# Altium schematic sheet Power.SchDoc(Power)
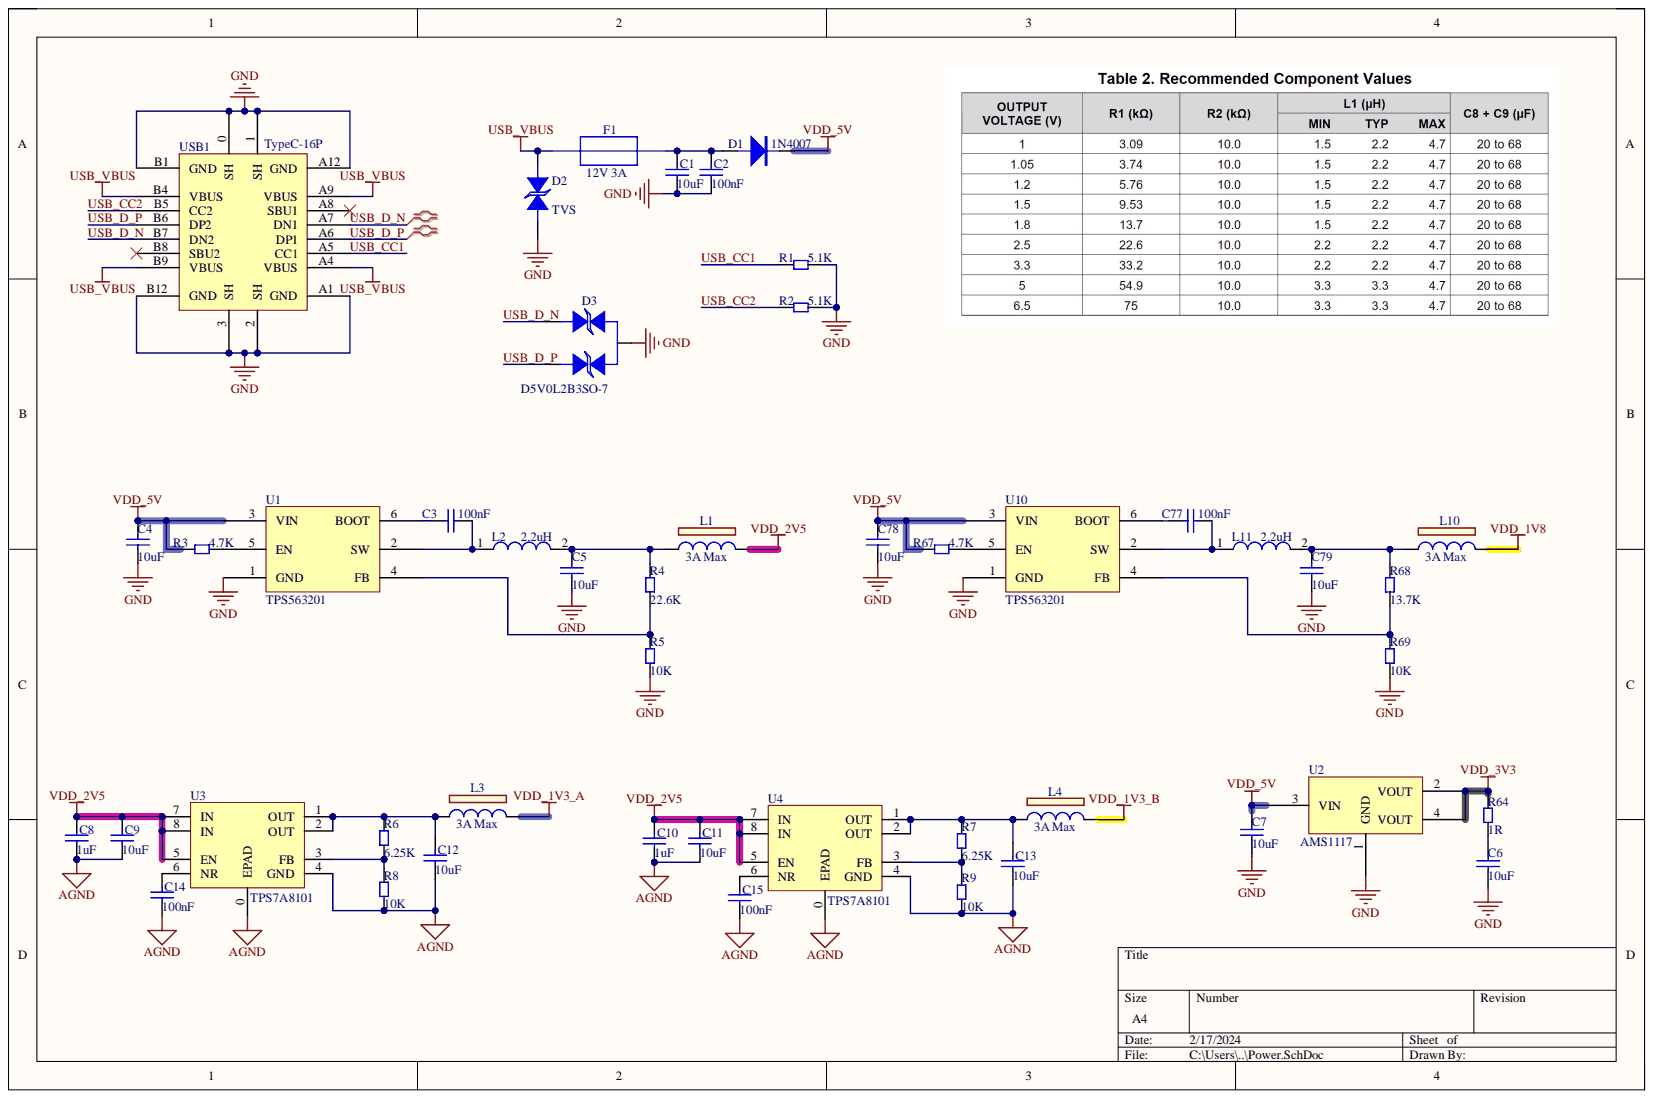
[diagram p0: Power.SchDoc]

## Parts
C1  10uF  [C0603]
C2  100nF  [C0603]
C3  100nF  [C0402]
C4  10uF  [C0603]
C5  10uF  [C0402]
C6  10uF  [C0603]
C7  10uF  [C0603]
C8  1uF  [C0402]
C9  10uF  [C0402]
C10  1uF  [C0402]
C11  10uF  [C0402]
C12  10uF  [C0402]
C13  10uF  [C0402]
C14  100nF  [C0402]
C15  100nF  [C0402]
C77  100nF  [C0402]
C78  10uF  [C0603]
C79  10uF  [C0402]
D1  1N4007  [SOD-123]
D2  TVS  [SOD-123]
D3  D5V0L2B3SO-7  [SOT23-3]
F1  12V 3A  [R0603]
L1  3A Max  [L0603]
L2  2.2uH  [SMD-2520]
L3  3A Max  [L0603]
L4  3A Max  [L0603]
L10  3A Max  [L0603]
L11  2.2uH  [SMD-2520]
R1  5.1K  [R0402]
R2  5.1K  [R0402]
R3  4.7K  [R0402]
R4  22.6K  [R0402]
R5  10K  [R0402]
R6  6.25K  [R0402]
R7  6.25K  [R0402]
R8  10K  [R0402]
R9  10K  [R0402]
R64  1R  [R0402]
R67  4.7K  [R0402]
R68  13.7K  [R0402]
R69  10K  [R0402]
U1  TPS563201  [SOT23-6]
U2  AMS1117  [SOT223-3]
U3  TPS7A8101  [VSON-8-EP]
U4  TPS7A8101  [VSON-8-EP]
U10  TPS563201  [SOT23-6]
USB1  TypeC-16P  [TypeC-16P]

## Nets
AGND: C8.1 C9.1 C10.1 C11.1 C12.1 C13.1 C14.1 C15.1 R8.1 R9.1 U3.0(EPAD) U3.4(GND) U4.0(EPAD) U4.4(GND)
GND: C1.1 C2.1 C4.1 C5.1 C6.1 C7.1 C78.1 C79.1 D2.2 D3.3 R1.1 R2.1 R5.1 R69.1 U1.1(GND) U2.1(GND) U10.1(GND) USB1.0(SH) USB1.1(SH) USB1.2(SH) USB1.3(SH) USB1.A1(GND) USB1.A12(GND) USB1.B1(GND) USB1.B12(GND)
USB_VBUS: D2.1 F1.1 USB1.A4(VBUS) USB1.A9(VBUS) USB1.B4(VBUS) USB1.B9(VBUS)
VDD_1V3_A: L3.2
VDD_1V3_B: L4.2
VDD_1V8: L10.2
VDD_2V5: C8.2 C9.2 C10.2 C11.2 L1.2 U3.5(EN) U3.7(IN) U3.8(IN) U4.5(EN) U4.7(IN) U4.8(IN)
VDD_3V3: R64.2 U2.2(VOUT) U2.4(VOUT)
VDD_5V: C4.2 C7.2 C78.2 D1.1 R3.2 R67.2 U1.3(VIN) U2.3(VIN) U10.3(VIN)
USB_CC1: R1.2 USB1.A5(CC1)
USB_CC2: R2.2 USB1.B5(CC2)
USB_D_N: D3.1 USB1.A7(DN1) USB1.B7(DN2)
USB_D_P: D3.2 USB1.A6(DP1) USB1.B6(DP2)
NetC1_2: C1.2 C2.2 D1.2 F1.2
NetC3_1: C3.1 L2.1 U1.2(SW)
NetC3_2: C3.2 U1.6(BOOT)
NetC5_2: C5.2 L1.1 L2.2 R4.2
NetC6_2: C6.2 R64.1
NetC12_2: C12.2 L3.1 R6.2 U3.1(OUT) U3.2(OUT)
NetC13_2: C13.2 L4.1 R7.2 U4.1(OUT) U4.2(OUT)
NetC14_2: C14.2 U3.6(NR)
NetC15_2: C15.2 U4.6(NR)
NetC77_1: C77.1 L11.1 U10.2(SW)
NetC77_2: C77.2 U10.6(BOOT)
NetC79_2: C79.2 L10.1 L11.2 R68.2
NetR3_1: R3.1 U1.5(EN)
NetR4_1: R4.1 R5.2 U1.4(FB)
NetR6_1: R6.1 R8.2 U3.3(FB)
NetR7_1: R7.1 R9.2 U4.3(FB)
NetR67_1: R67.1 U10.5(EN)
NetR68_1: R68.1 R69.2 U10.4(FB)

Not connected: USB1 A8(SBU1) B8(SBU2)

## Library data of the parts
C1-C15 C77-C79 = Cap_NP: CAP no polarity; Component Kind: Standard; Library Name: Common.SchLib
D1 = Diode: Component Kind: Standard; Description: Diode; Library Name: Common.SchLib
D2 = Diode_TVS: TVS Diode; Component Kind: Standard; Library Name: Common.SchLib
D3 = Diode_DTVS: Dual TVS; Component Kind: Standard; Library Name: Common.SchLib
F1 = Fuse: Component Kind: Standard; Description: Fuse; Library Name: Common.SchLib
L1 L3 L4 L10 = Ferrite-bead: Component Kind: Standard; Description: Ferrite-bead; Library Name: Common.SchLib
L2 L11 = Inductor: Component Kind: Standard; Description: Inductor; Library Name: Common.SchLib
R1-R9 R64 R67-R69 = Res: Component Kind: Standard; Description: Res; Library Name: Common.SchLib
U1 U10 = TPS563201: 3A Sync DC-DC; Component Kind: Standard; Library Name: ZYNQ_Core.SchLib
U2 = AMS1117: 1A LDO; Component Kind: Standard; Library Name: Common.SchLib
U3 U4 = TPS7A8101: Low noise ldo with 1A; Component Kind: Standard; Library Name: ZYNQ_SDR.SCHLIB
USB1 = TypeC-16P: Component Kind: Standard; Description: TypeC-16P; Library Name: Common.SchLib
